# Altium schematic sheet ArcX SoS.SchDoc
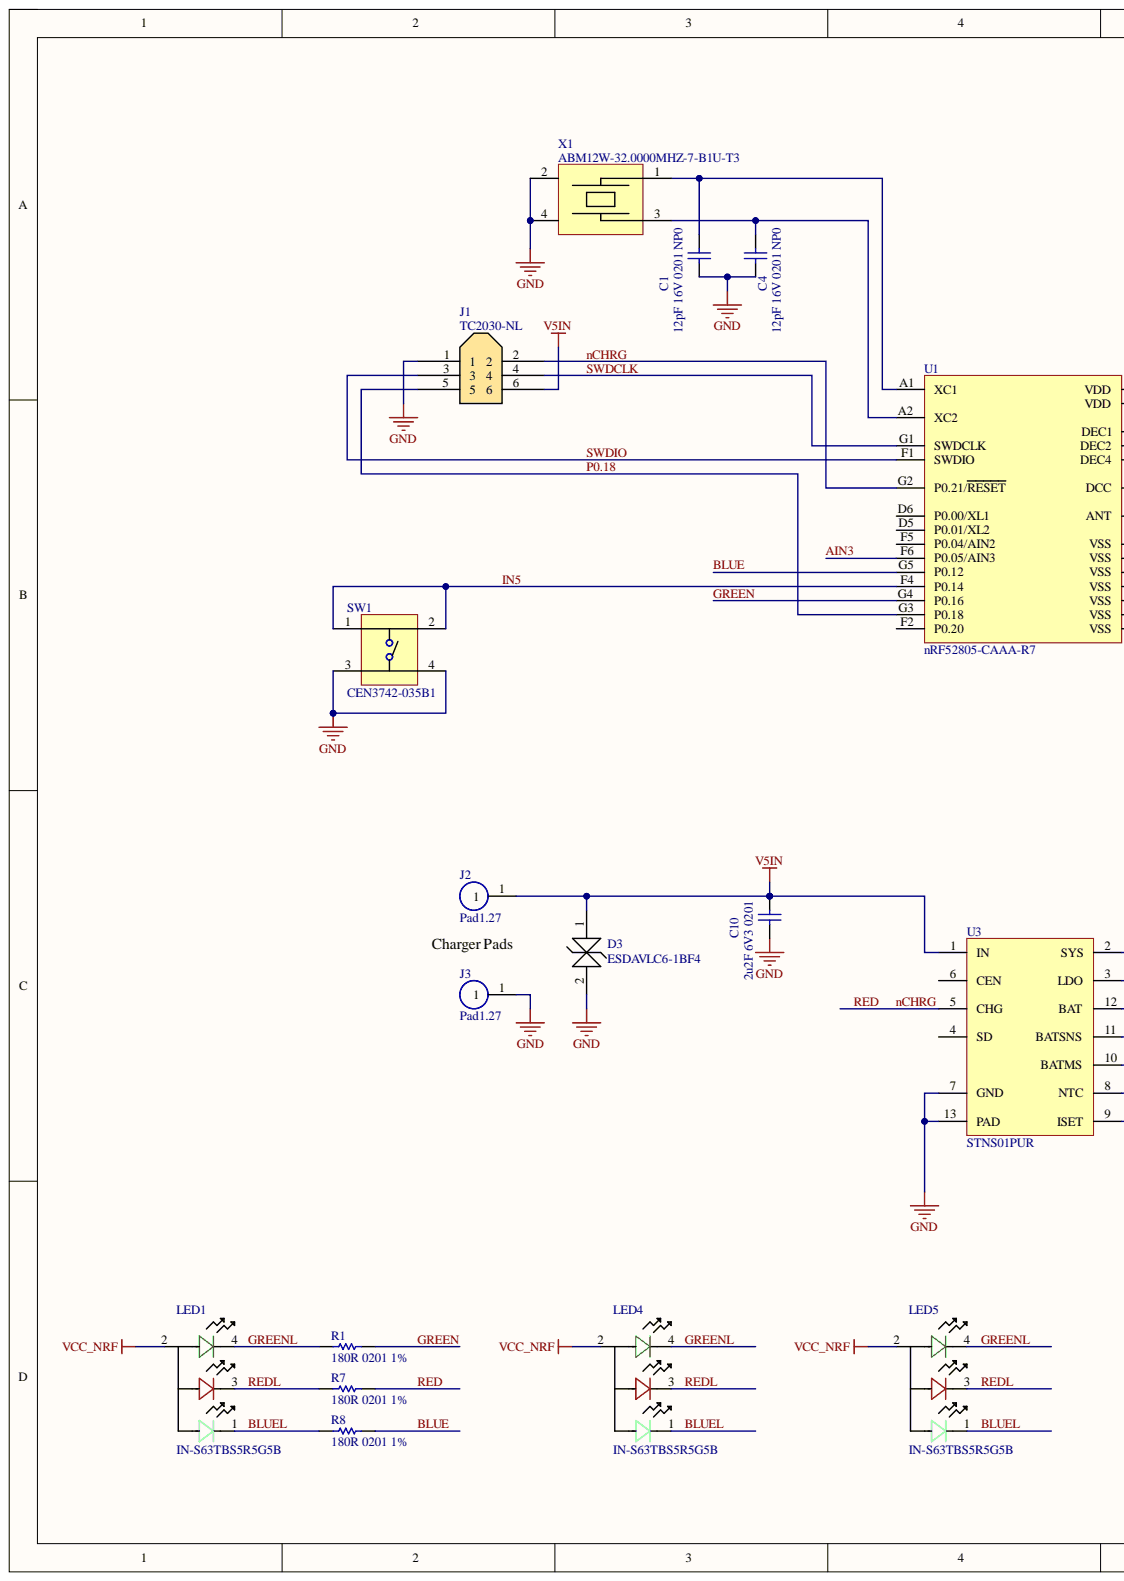
[diagram p0: ArcX SoS.SchDoc, left]
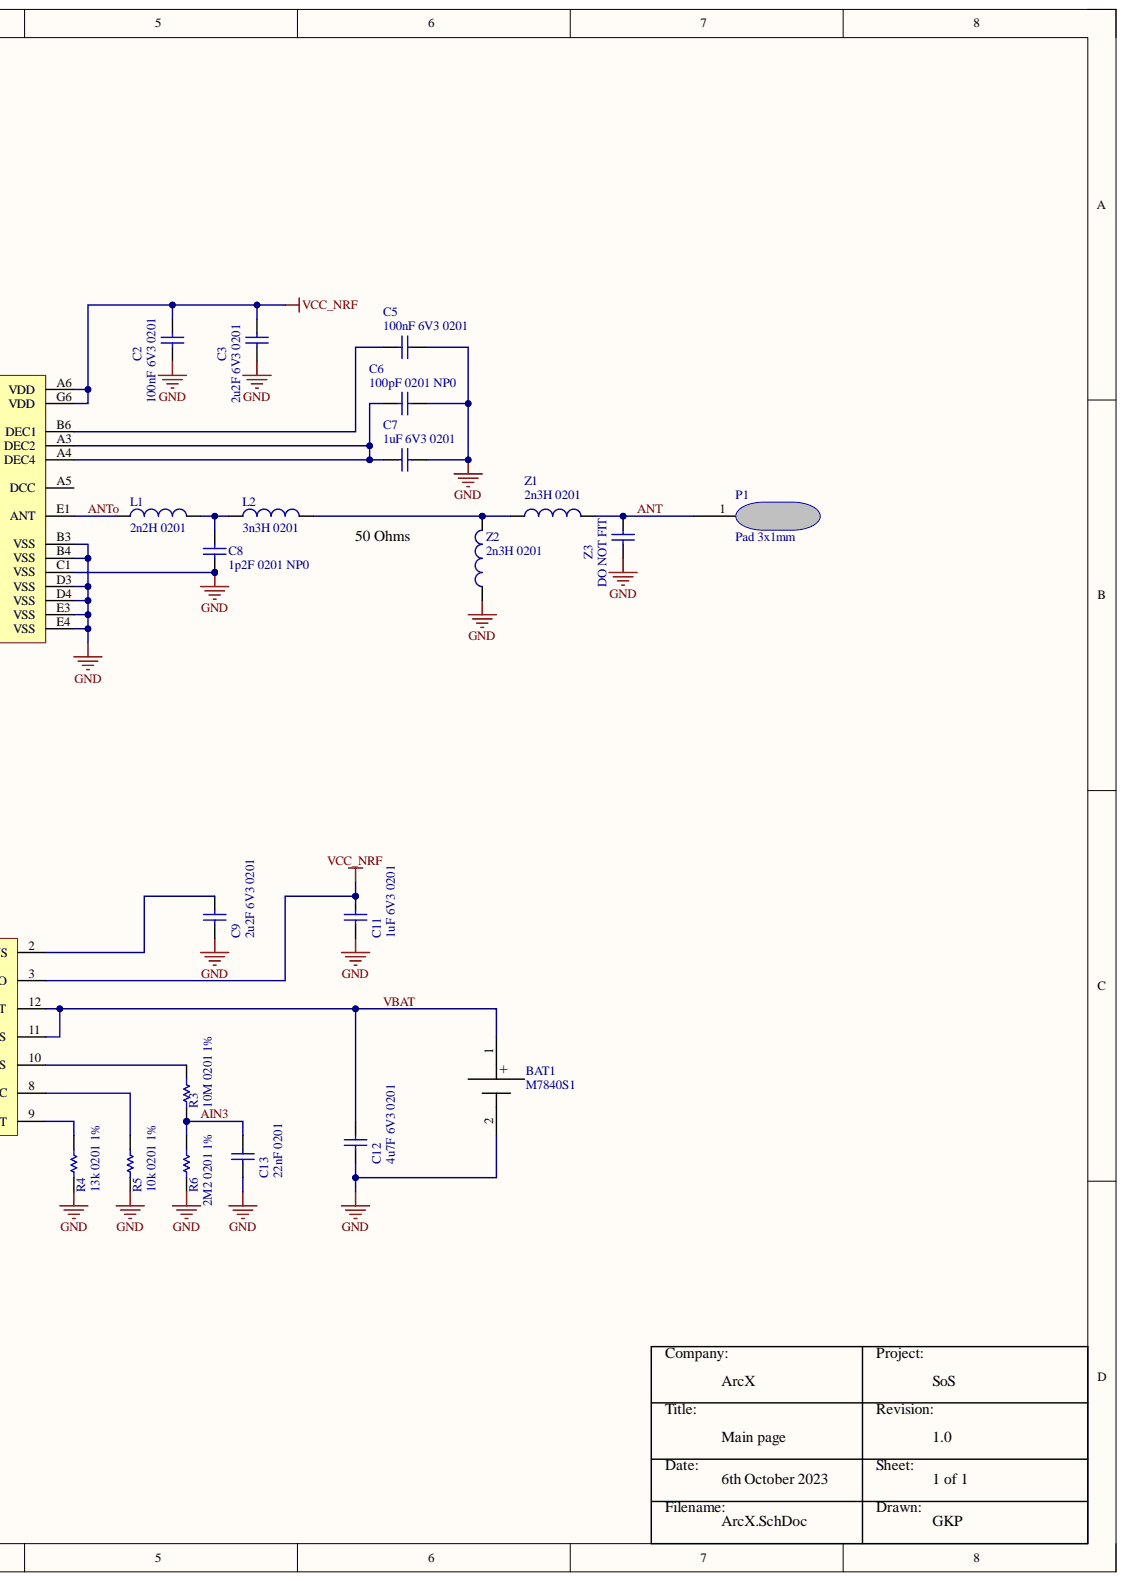
[diagram p1: ArcX SoS.SchDoc, right]

## Parts
BAT1  M7840S1  [M7840S1 coin cell]
C1  12pF 16V 0201 NP0  [0201panERJ]
C2  100nF 6V3 0201  [0201panERJ]
C3  2u2F 6V3 0201  [0201panERJ]
C4  12pF 16V 0201 NP0  [0201panERJ]
C5  100nF 6V3 0201  [0201panERJ]
C6  100pF 0201 NP0  [0201panERJ]
C7  1uF 6V3 0201  [0201panERJ]
C8  1p2F 0201 NP0  [0201panERJ]
C9  2u2F 6V3 0201  [0201panERJ]
C10  2u2F 6V3 0201  [0201panERJ]
C11  1uF 6V3 0201  [0201panERJ]
C12  4u7F 6V3 0201  [0201panERJ]
C13  22nF 0201  [0201panERJ]
D3  ESDAVLC6-1BF4  [ESD0201]
J1  TC2030-NL  [TC2030-MCP-NL]  (no BOM)
J2  Pad1.27  [Pad1.27]  (no BOM)
J3  Pad1.27  [Pad1.27]  (no BOM)
L1  2n2H 0201  [0201IND]
L2  3n3H 0201  [0201IND]
LED1  IN-S63TBS5R5G5B  [LED IN-S63TBS5R5G5B]
LED4  IN-S63TBS5R5G5B  [LED IN-S63TBS5R5G5B]
LED5  IN-S63TBS5R5G5B  [LED IN-S63TBS5R5G5B]
P1  Pad 3x1mm  [Pad 0.5x0.5mm - thru]  (no BOM)
R1  180R 0201 1%  [0201RES]
R3  10M 0201 1%  [0201RES]
R4  13k 0201 1%  [0201RES]
R5  10k 0201 1%  [0201RES]
R6  2M2 0201 1%  [0201RES]
R7  180R 0201 1%  [0201RES]
R8  180R 0201 1%  [0201RES]
SW1  CEN3742-035B1  [CEN3742-035B1_1]
U1  nRF52805-CAAA-R7  [nRF52805]
U3  STNS01PUR  [ST DFN12L]
X1  ABM12W-32.0000MHZ-7-B1U-T3  [Abracon ABM12V]
Z1  2n3H 0201  [0201IND]
Z2  2n3H 0201  [0201IND]
Z3  DO NOT FIT  [0201panERJ]

## Nets
GND: BAT1.2 C1.2 C2.1 C3.1 C4.2 C5.2 C6.2 C7.2 C8.1 C9.1 C10.1 C11.1 C12.2 C13.2 D3.2 J1.1 J3.1 R4.2 R5.2 R6.2 SW1.3 SW1.4 U1.B3(VSS) U1.B4(VSS) U1.C1(VSS) U1.D3(VSS) U1.D4(VSS) U1.E3(VSS) U1.E4(VSS) U3.7(GND) U3.13(PAD) X1.2 X1.4 Z2.1 Z3.1
V5IN: C10.2 D3.1 J1.6 J2.1 U3.1(IN)
VCC_NRF: C2.2 C3.2 C11.2 LED1.2 LED4.2 LED5.2 U1.A6(VDD) U1.G6(VDD) U3.3(LDO)
AIN3: C13.1 R3.2 R6.1 U1.F6(P0.05/AIN3)
ANT: P1.1 Z1.2 Z3.2
ANTo: L1.1 U1.E1(ANT)
BLUE: R8.1 U1.G5(P0.12)
BLUEL: LED1.1 LED4.1 LED5.1 R8.2
GREEN: R1.1 U1.G4(P0.16)
GREENL: LED1.4 LED4.4 LED5.4 R1.2
IN5: SW1.1 SW1.2 U1.F4(P0.14)
nCHRG: J1.2 R7.1 U1.G2(P0.21/RESET) U3.5(CHG)
P0.18: J1.5 U1.G3(P0.18)
REDL: LED1.3 LED4.3 LED5.3 R7.2
SWDCLK: J1.4 U1.G1(SWDCLK)
SWDIO: J1.3 U1.F1(SWDIO)
VBAT: BAT1.1 C12.1 U3.11(BATSNS) U3.12(BAT)
NetC1_1: C1.1 U1.A1(XC1) X1.1
NetC4_1: C4.1 U1.A2(XC2) X1.3
NetC5_1: C5.1 U1.B6(DEC1)
NetC6_1: C6.1 C7.1 U1.A3(DEC2) U1.A4(DEC4)
NetC8_2: C8.2 L1.2 L2.1
NetC9_2: C9.2 U3.2(SYS)
NetL2_2: L2.2 Z1.1 Z2.2
NetR3_1: R3.1 U3.10(BATMS)
NetR4_1: R4.1 U3.9(ISET)
NetR5_1: R5.1 U3.8(NTC)

Not connected: U1 A5(DCC) D5(P0.01/XL2) D6(P0.00/XL1) F2(P0.20) F5(P0.04/AIN2); U3 4(SD) 6(CEN)

## Library data of the parts
BAT1 = Battery M7840S1: Coin cell, 3.7V, 17mAh. 7.9mm x 4.1mm; Component Kind: Standard; Manufacturer Part Number 1: M7840S1; Supplier 1: Shenzhen Coincell Battery Co., Ltd.; Library Name: ArcX.SchLib
C1-C13 Z3 = Cap0201: Standard 0201 CAP; Case-EIA: 0402; Component Kind: Standard; Max Thickness: 0.6 mm; Technology: SMT; Library Name: ArcX.SchLib
  C1 C4: Supplier 1: Digikey; Supplier Part Number 1: 490-17803-1-ND
  C2 C5: Supplier 1: Digikey; Supplier Part Number 1: 1276-6439-1-ND
  C3 C9 C10: Supplier 1: Digikey; Supplier Part Number 1: 311-3473-1-ND
  C6: Supplier 1: Digikey; Supplier Part Number 1: 490-3160-1-ND
  C7 C11: Supplier 1: Digikey; Supplier Part Number 1: 311-1613-1-ND
  C8: Supplier 1: Digikey; Supplier Part Number 1: 490-GRM0335C1H1R2WA01DCT-ND
  C12: Supplier 1: Digikey; Supplier Part Number 1: 1253-CM03X5R475M06AH055CT-ND
  C13: Supplier 1: Digikey; Supplier Part Number 1: 587-6154-1-ND
D3 = ESDAVLC6-1BF4: 0201 Inductor; Manufacturer 1: STMicroelectronics; Component Kind: Standard; Manufacturer Part Number 1: ESDAVLC6-1BF4; Supplier 1: Digikey; Supplier Part Number 1: 497-13094-2-ND; Library Name: ArcX.SchLib
J1 = TC2030-NL: Tag connect TC2030-NL no-legs; Library Name: TC2030.SchLib
J2 J3 = Charger pad 1.27: Single sided pad. Copper only. Not a component for BOM.; Library Name: ArcX.SchLib
L1 = Inductor0201: 0201 Inductor; Manufacturer 1: Murata Electronics; Manufacturer Part Number 1: LQP03TN2N2C02D; Component Kind: Standard; Supplier 1: DigiKey; Supplier Part Number 1: 490-5554-2-ND; Library Name: ArcX.SchLib
L2 = Inductor0201: 0201 Inductor; Manufacturer 1: Murata Electronics; Manufacturer Part Number 1: LQP03PN3N3C02D; Component Kind: Standard; Supplier 1: DigiKey; Supplier Part Number 1: 490-9615-2-ND; Library Name: ArcX.SchLib
LED1 LED4 LED5 = LED IN-S63TBS5R5G5B: Red, Green, Blue (RGB) 625nm Red, 530nm Green, 473nm Blue LED Indication - Discrete 2.2V Red, 3V Green, 3V Blue 0603 (1608 Metric); Manufacturer 1: Inolux; Component Kind: Standard; Manufacturer Part Number 1: IN-S63TBS5R5G5B; Supplier 1: Digi-Key; Supplier Part Number 1: 1830-IN-S63TBS5R5G5BTR-ND; Library Name: Active Tran Diode.SchLib
P1 = Pad 0.5x0.5mm_thru: thru pad 0.5x0.5mm; Library Name: Connectors pads.SchLib
R1 R7 R8 = Res0201: Value: ?k; Component Kind: Standard; Description: 180R 0201 1%; Library Name: ArcX.SchLib
R3-R6 = Res0201: Standard 0201 RES; Value: ?k; Component Kind: Standard; Library Name: ArcX.SchLib
SW1 = CEN3742-035B1: CEN3742-035B1 Tact Switch; Component Kind: Standard; Library Name: Connectors pads.SchLib; Manufacturer Part Number 1: CEN3742-035B1; Supplier 1: ecomponents ltd.; Supplier Part Number 1: CEN3742-035B1
U1 = nRF52805: Bluetooth 5.0, 2.4 GHz transceiver; Manufacturer 1: Nordic Semiconductor ASA; Component Kind: Standard; Manufacturer Part Number 1: NRF52805-CAAA-R7; Supplier 1: Digikey; Supplier Part Number 1: 1490-NRF52805-CAAA-R7TR-ND; Library Name: ArcX.SchLib
U3 = STNS01: IC BATT CHG LI-ION 1CELL 12DFN; Manufacturer 1: STMicroelectronics; Component Kind: Standard; Manufacturer Part Number 1: STNS01PUR; Supplier 1: Digikey; Supplier Part Number 1: 497-13880-2-ND; Library Name: ArcX.SchLib
X1 = Abracon Crystal: 32MHz Xtal 7pF; Manufacturer 1: Abracon LLC; Component Kind: Standard; Manufacturer Part Number 1: ABM12W-32.0000MHZ-7-B1U-T3; Supplier 1: Digi-Key; Supplier Part Number 1: 535-ABM12W-32.0000MHZ-7-B1U-T3TR-ND; Library Name: ArcX.SchLib
Z1 Z2 = Inductor0201: 2n3H 0201 Inductor; Manufacturer 1: Murata Electronics; Manufacturer Part Number 1: LQP03HQ2N3B02D; Component Kind: Standard; Supplier 1: DigiKey; Supplier Part Number 1: 490-13901-2-ND; Library Name: ArcX.SchLib
